# Altium schematic sheet reefvolt_power_essentials_top.SchDoc
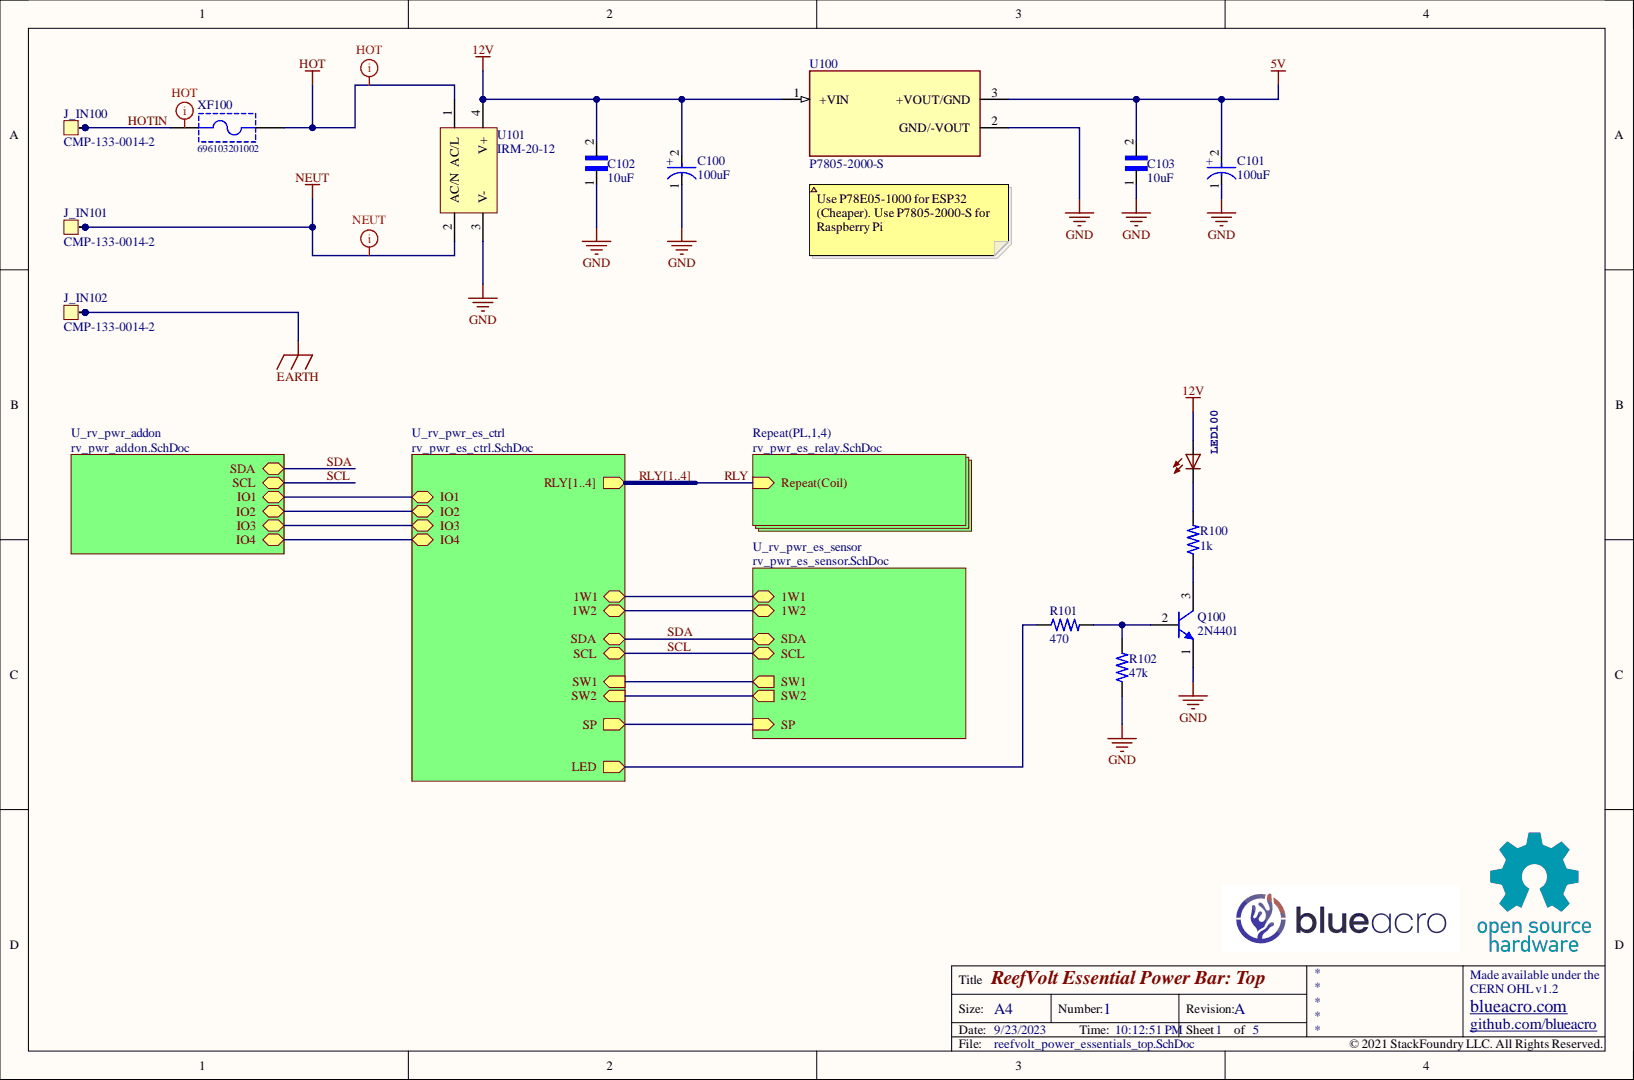
[diagram p0: reefvolt_power_essentials_top.SchDoc]

## Parts
C100  value 100uF  [FP-RAD-TH-D_8_0_5-L_11_5-MFG]  (hidden comment: CAP 100uF 25V Aluminum 8mm Through Hole)
C101  value 100uF  [FP-RAD-TH-D_8_0_5-L_11_5-MFG]  (hidden comment: CAP 100uF 25V Aluminum 8mm Through Hole)
C102  value 10uF  [CAPRB250W50L550T350H600]  (hidden comment: FG16X7R1E106KRT06)
C103  value 10uF  [CAPRB250W50L550T350H600]  (hidden comment: FG16X7R1E106KRT06)
J_IN100  CMP-133-0014-2  [0197054203]
J_IN101  CMP-133-0014-2  [0197054203]
J_IN102  CMP-133-0014-2  [0197054203]
LED100  (no value)  [LED5MM]
Q100  2N4401  [ONSC-TO-92-3-29-11]
R100  value 1k  [0207/10]  (hidden comment: 1k TH 0207 5% 0.25W)
R101  value 470  [0207/10]  (hidden comment: 470 TH 0207 5% 0.25W)
R102  value 47k  [0207/10 - Cloned]  (hidden comment: 47k TH 0207 5% 1/4W)
U100  P7805-2000-S  [CONV_P7805-2000]
U101  IRM-20-12  [MEANW-IRM-20-12]
XF100  696103201002  [696103201002]

## Nets
5V: C101.2 C103.2 U100.3
12V: C100.2 C102.2 LED100.A U100.1 U101.4(V+)
EARTH: J_IN102.1 J_IN102.2 J_IN102.3
GND: C100.1 C101.1 C102.1 C103.1 Q100.1 R102.1 U100.2 U101.3(V-)
HOT: U101.1(AC/L) XF100.2
NEUT: J_IN101.1 J_IN101.2 J_IN101.3 U101.2(AC/N)
HOTIN: J_IN100.1 J_IN100.2 J_IN100.3 XF100.1
NetLED100_K: LED100.K R100.2
NetQ100_2: Q100.2 R101.2 R102.2
NetQ100_3: Q100.3 R100.1
NetR101_1: R101.1
1W1:
1W2:
IO1:
IO2:
IO3:
IO4:
RLY1:  [also labelled RLY]
RLY2:  [also labelled RLY]
RLY3:  [also labelled RLY]
RLY4:  [also labelled RLY]
SCL:
SDA:
SP:
SW1:
SW2:

## Library data of the parts
C100 C101 = CMP-001-00110-1: Cap Aluminum 100uF 25V 20% (8 X 11.5mm) Radial 3.5mm 500mA 2000 hr 125°C Bulk; Dielectric Material: Aluminium; Case/Package: Radial; Component Kind: Standard; Diameter: 8mm; Library Name: StackFoundry LLC
C102 C103 = CMP-233-000000-3: FG Series 10 uF 25 V ±10% Tolerance X7R Radial Multilayer Ceramic Capacitor; MF: TDK; Case/Package: Radial; Check_prices: https://www.snapeda.com/parts/FG16X7R1E106KRT06/TDK/view-part/?ref=eda; Component Kind: Standard; Component Type: Standard; Design Item ID: FG16X7R1E106KRT06; Digi-Key_Part_Number: 445-173156-1-ND; Height - Seated (Max): 0.236inch; Lead Pitch: 0.098inch; MP: FG16X7R1E106KRT06; Package: Radial TDK; Pin Count: 2; Purchase-URL: https://pricing.snapeda.com/search/part/FG16X7R1E106KRT06/?ref=eda; SnapEDA_Link: https://www.snapeda.com/parts/FG16X7R1E106KRT06/TDK/view-part/?ref=snap; Source: FG16X7R1E106KRT06.SchLib; Library Name: StackFoundry LLC
J_IN100-J_IN102 = CMP-133-0014-2: Molex  0197054203; Component Kind: Standard; Design Item ID: TAB_QUICKCON; Source: con-molex.SCHLIB; Library Name: StackFoundry LLC
LED100 = LED5MM: Component Kind: Standard; Library Name: general.IntLib
Q100 = CMP-013-00039-2: Transistor NPN Silicon 40V IC=600ma TO-92 Case General Purpose Amplifier And Switch; Collector Base Voltage (VCBO): 60V; Collector Emitter Saturation Voltage: 750mV; MF: Rectron; Availability: In Stock; Case/Package: TO-92; Collector-emitter Voltage-Max: 40V; Component Kind: Standard; Component Type: Standard; Design Item ID: 2N4401; MP: 2N4401; Package: TO-226-3 Rectron; Pin Count: 3; Price: None; Purchase-URL: https://pricing.snapeda.com/search/part/2N3904/?ref=eda; SnapEDA_Link: https://www.snapeda.com/parts/2N3904/Rectron/view-part/?ref=snap; Library Name: StackFoundry LLC
R100 = CMP-009-00215-2: Res Carbon Film 1K Ohm 5% 1/4W -500ppm/°C to 350ppm/°C Conformal AXL Thru-Hole Paper T/R; Case/Package: Conformal; Component Kind: Standard; Construction: Cylindrical; Contact Plating: Tin,Matte; Diameter: 2.4mm; Library Name: StackFoundry LLC
R101 = CMP-009-00217-1: Res Carbon Film 470 Ohm 5% 1/4W -500ppm/°C to 350ppm/°C Conformal AXL Thru-Hole Paper T/R; Case/Package: Conformal; Component Kind: Standard; Construction: Cylindrical; Contact Plating: Tin,Matte; Diameter: 2.4mm; Library Name: StackFoundry LLC
R102 = CMP-009-00220-1: Res Carbon Film 47K Ohm 5% 1/4W -500ppm/°C to 350ppm/°C Conformal AXL Thru-Hole Paper T/R; Lead Diameter: 0.55mm; Case/Package: Axial; Component Kind: Standard; Contact Plating: Tin,Matte; Diameter: 2.4mm; Library Name: StackFoundry LLC
U100 = CMP-214-000001-1: Module DC-DC 24VIN 1-OUT 5V 2A 10W 3-Pin SIP Module Tube; Efficiency: 90%; Max Supply Voltage: 36V; Component Kind: Standard; Max Operating Temperature: 85°C; Max Output current: 2A; Library Name: StackFoundry LLC
U101 = CMP-2000-07460-1: Single Output Encapsulated Type, 12 V, 1.8 A, 21.6 W, -40 to 85 degC, 4-Pin THD, RoHS; Manufacturer: Mean Well; Approvals: CB; Current - Output 1: 1.8A; Efficiency: 84%; Max Output Power: 21.6W; Number of Outputs: 1; Voltage - Output 1: 12V; Component Kind: Standard; Datasheet URL: http://datasheet.octopart.com/IRM-20-12-Mean-Well-datasheet-42021592.pdf; Datasheet Version: 06/2015; Height: 24mm; Lead Free: LeadFree; Length: 53mm; Library Name: Altium Content Vault; Manufacturer URL: http://www.meanwellusa.com/; Max Operating Temperature: 70degC; Min Operating Temperature: -30degC; Mount: ThroughHole; Mounting Technology: ThroughHole; Number of Pins: 4; Output Current: 1.8A; Output Power: 21.6W; Package Description: 4-Pin Through Hole Device, Body 52.4 x 27.2 mm, Height 24 mm; Package Quantity: 240; Package Reference: IRM-20-12-4; Packaging: Bulk; RoHSCompliant: true; Weight: 2.081164oz; Width: 28mm
XF100 = CMP-005-00015-1: WR-FSH Fuse Holder Blocks Fuse Holder Blocks Clip Cover for PCB - THR Version; Component Kind: Standard; Library Name: StackFoundry LLC
